# Altium schematic sheet 3_CLK_LMK04828.SchDoc
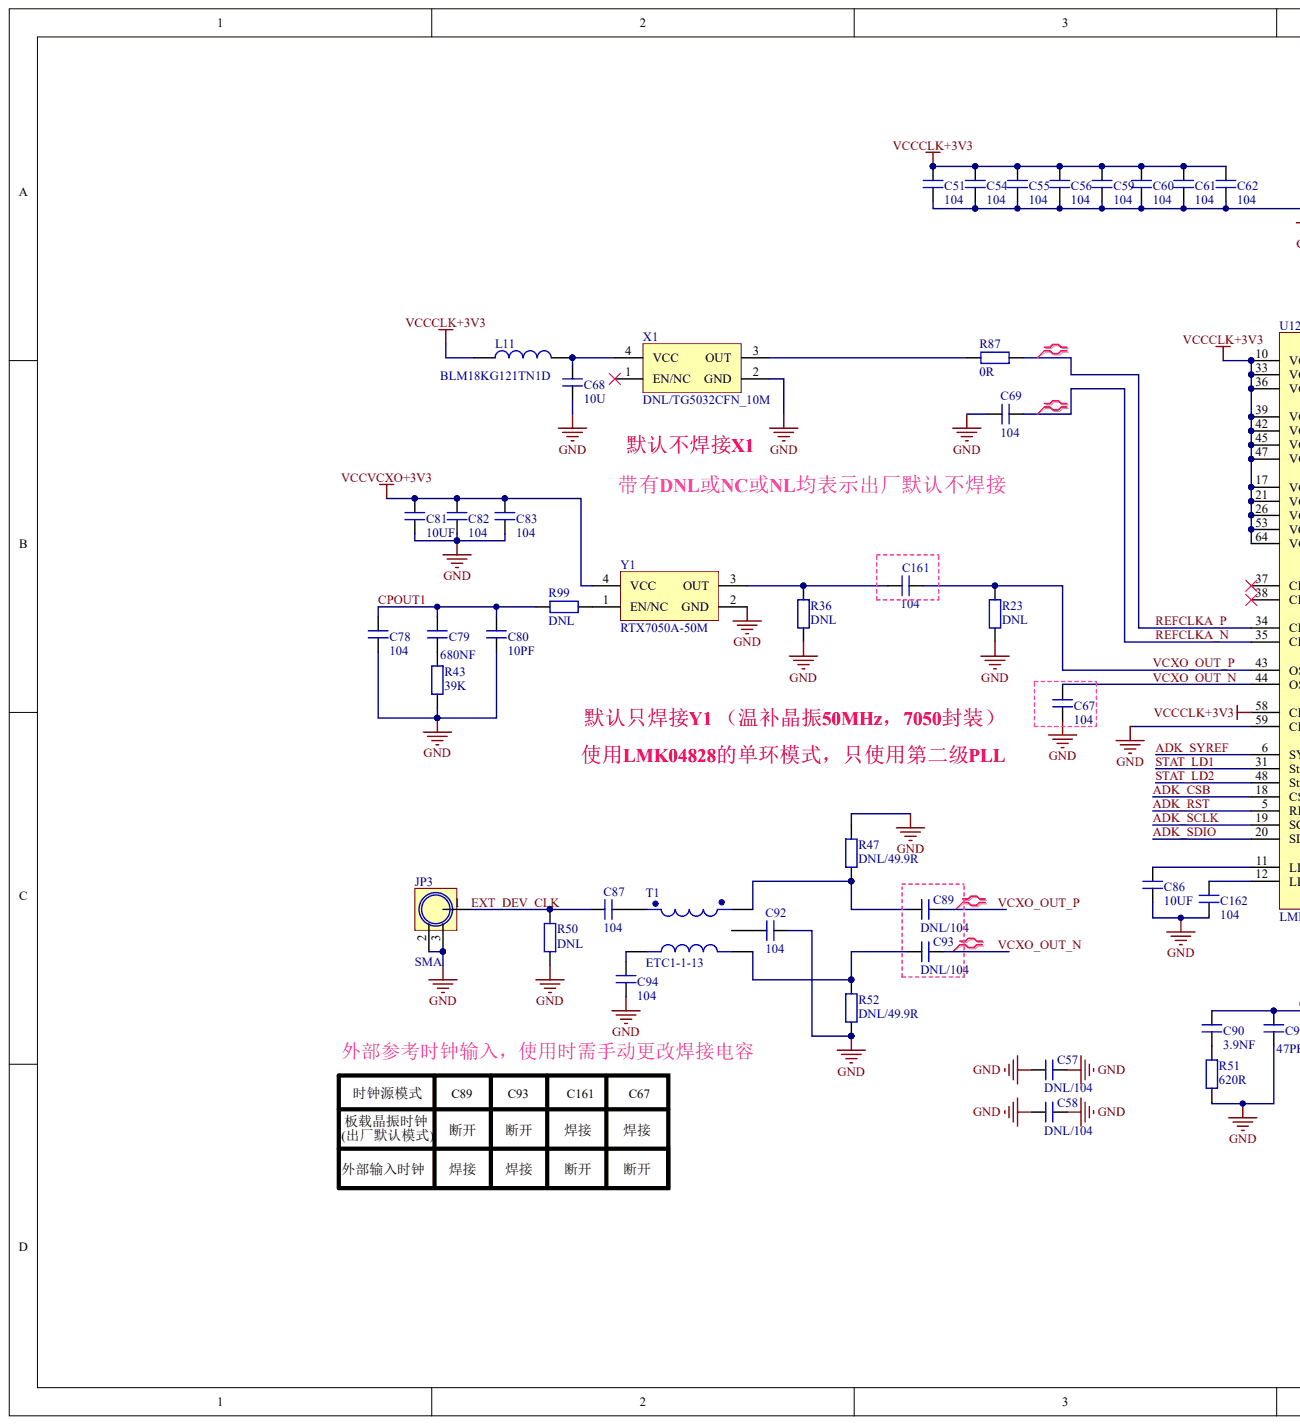
[diagram p0: 3_CLK_LMK04828.SchDoc, left]
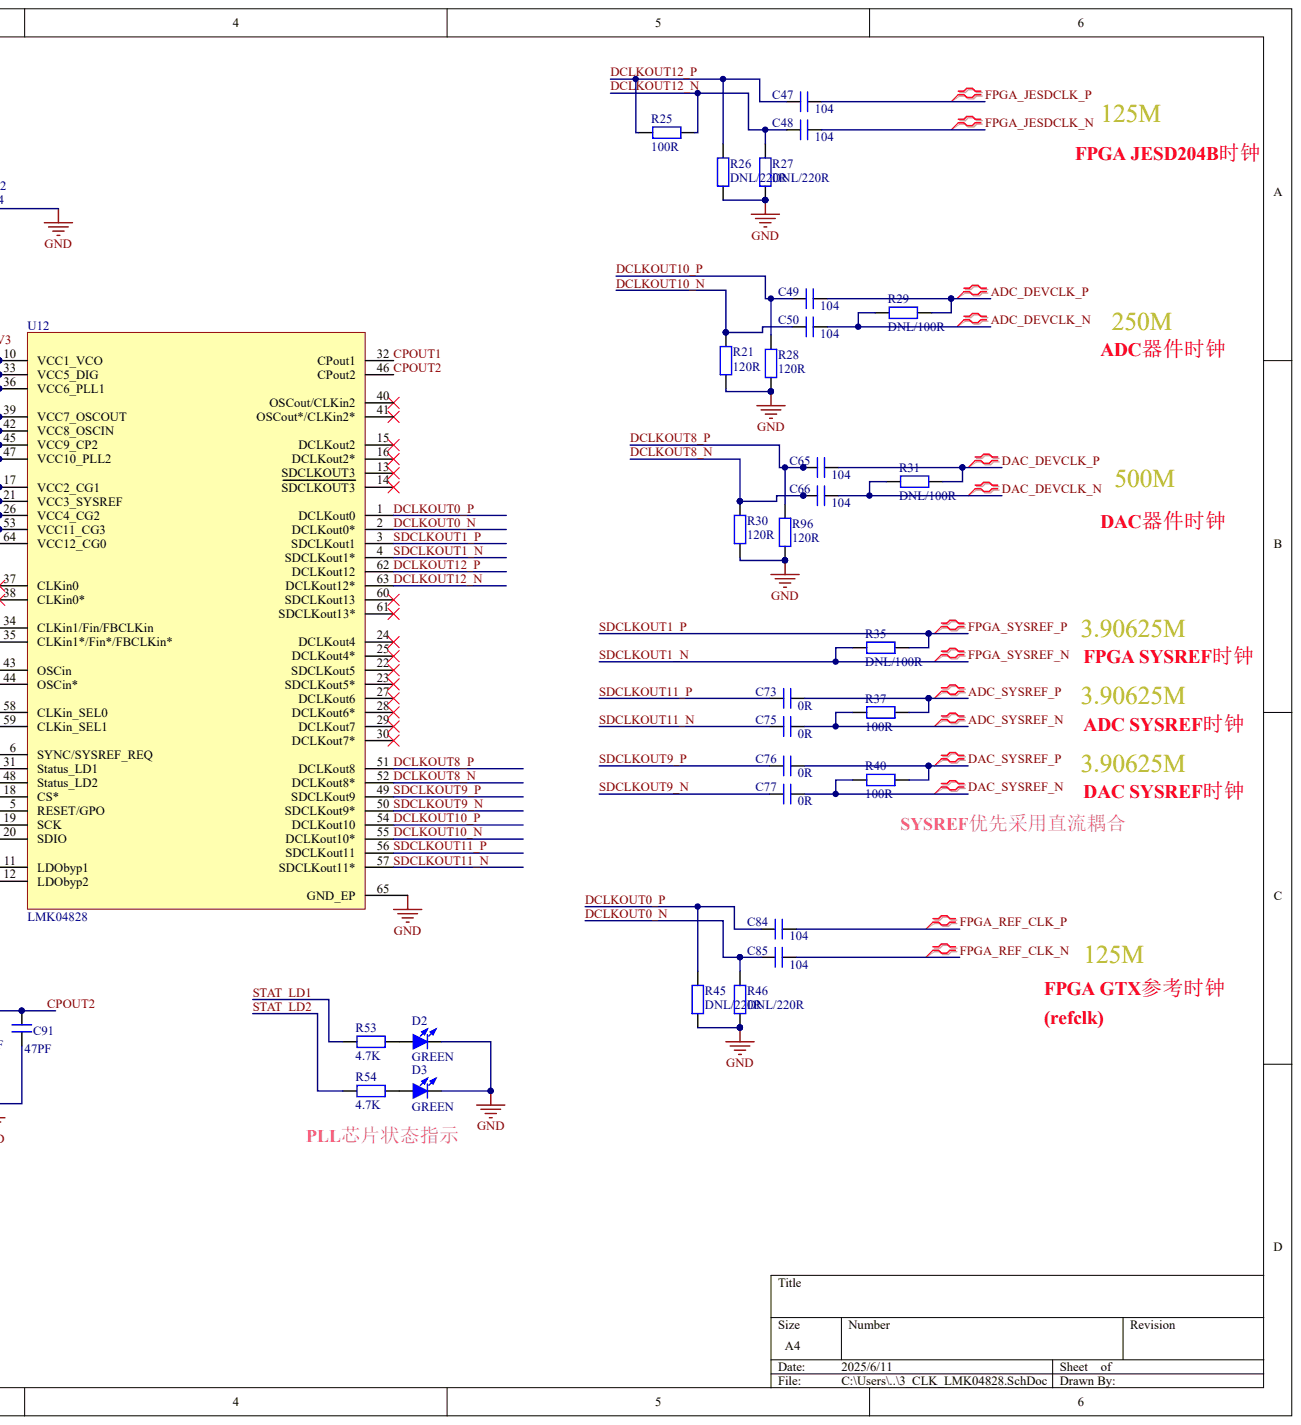
[diagram p1: 3_CLK_LMK04828.SchDoc, right]

## Parts
C47  104
C48  104
C49  104
C50  104
C51  104
C54  104
C55  104
C56  104
C57  DNL/104
C58  DNL/104
C59  104
C60  104
C61  104
C62  104
C65  104
C66  104
C67  104
C68  10U
C69  104
C73  0R
C75  0R
C76  0R
C77  0R
C78  104
C79  680NF
C80  10PF
C81  10UF
C82  104
C83  104
C84  104
C85  104
C86  10UF
C87  104
C89  DNL/104
C90  3.9NF
C91  47PF
C92  104
C93  DNL/104
C94  104
C161  104
C162  104
D2  GREEN
D3  GREEN
JP3  SMA
L11  BLM18KG121TN1D
R21  120R
R23  DNL
R25  100R
R26  DNL/220R
R27  DNL/220R
R28  120R
R29  DNL/100R
R30  120R
R31  DNL/100R
R35  DNL/100R
R36  DNL
R37  100R
R40  100R
R43  39K
R45  DNL/220R
R46  DNL/220R
R47  DNL/49.9R
R50  DNL
R51  620R
R52  DNL/49.9R
R53  4.7K
R54  4.7K
R87  0R
R96  120R
R99  DNL
T1  ETC1-1-13
U12  LMK04828
X1  DNL/TG5032CFN_10M
Y1  RTX7050A-50M

## Nets
GND: C51.1 C54.1 C55.1 C56.1 C57.1 C57.2 C58.1 C58.2 C59.1 C60.1 C61.1 C62.1 C67.1 C68.2 C69.1 C78.2 C80.2 C81.2 C82.2 C83.2 C86.2 C91.2 C92.1 C94.2 C162.1 D2.2 D3.2 JP3.2 JP3.3 R21.2 R23.1 R26.2 R27.2 R28.1 R30.2 R36.1 R43.1 R45.2 R46.2 R47.2 R50.2 R51.1 R52.1 R96.1 U12.59(CLKin_SEL1) U12.65(GND_EP) X1.2(GND) Y1.2(GND)
VCCCLK+3V3: C51.2 C54.2 C55.2 C56.2 C59.2 C60.2 C61.2 C62.2 L11.1 U12.10(VCC1_VCO) U12.17(VCC2_CG1) U12.21(VCC3_SYSREF) U12.26(VCC4_CG2) U12.33(VCC5_DIG) U12.36(VCC6_PLL1) U12.39(VCC7_OSCOUT) U12.42(VCC8_OSCIN) U12.45(VCC9_CP2) U12.47(VCC10_PLL2) U12.53(VCC11_CG3) U12.58(CLKin_SEL0) U12.64(VCC12_CG0)
VCCVCXO+3V3: C81.1 C82.1 C83.1 Y1.4(VCC)
ADC_DEVCLK_N: C50.2 R29.1
ADC_DEVCLK_P: C49.2 R29.2
ADC_SYSREF_N: C75.2 R37.1
ADC_SYSREF_P: C73.2 R37.2
ADK_CSB: U12.18(CS*)
ADK_RST: U12.5(RESET/GPO)
ADK_SCLK: U12.19(SCK)
ADK_SDIO: U12.20(SDIO)
ADK_SYREF: U12.6(SYNC/SYSREF_REQ)
CPOUT1: C78.1 C79.1 C80.1 R99.2 U12.32(CPout1)
CPOUT2: C90.1 C91.1 U12.46(CPout2)
DAC_DEVCLK_N: C66.2 R31.1
DAC_DEVCLK_P: C65.2 R31.2
DAC_SYSREF_N: C77.2 R40.1
DAC_SYSREF_P: C76.2 R40.2
DCLKOUT0_N: C85.1 R46.1 U12.2(DCLKout0*)
DCLKOUT0_P: C84.1 R45.1 U12.1(DCLKout0)
DCLKOUT8_N: C66.1 R30.1 U12.52(DCLKout8*)
DCLKOUT8_P: C65.1 R96.2 U12.51(DCLKout8)
DCLKOUT10_N: C50.1 R21.1 U12.55(DCLKout10*)
DCLKOUT10_P: C49.1 R28.2 U12.54(DCLKout10)
DCLKOUT12_N: C48.1 R25.2 R27.1 U12.63(DCLKout12*)
DCLKOUT12_P: C47.1 R25.1 R26.1 U12.62(DCLKout12)
EXT_DEV_CLK: C87.2 JP3.1 R50.1
FPGA_JESDCLK_N: C48.2
FPGA_JESDCLK_P: C47.2
FPGA_REF_CLK_N: C85.2
FPGA_REF_CLK_P: C84.2
FPGA_SYSREF_N: R35.1 U12.4(SDCLKout1*)
FPGA_SYSREF_P: R35.2 U12.3(SDCLKout1)
REFCLKA_N: C69.2 U12.35(CLKin1*/Fin*/FBCLKin*)
REFCLKA_P: R87.2 U12.34(CLKin1/Fin/FBCLKin)
SDCLKOUT9_N: C77.1 U12.50(SDCLKout9*)
SDCLKOUT9_P: C76.1 U12.49(SDCLKout9)
SDCLKOUT11_N: C75.1 U12.57(SDCLKout11*)
SDCLKOUT11_P: C73.1 U12.56(SDCLKout11)
STAT_LD1: R53.1 U12.31(Status_LD1)
STAT_LD2: R54.1 U12.48(Status_LD2)
VCXO_OUT_N: C67.2 C93.1 U12.44(OSCin*)
VCXO_OUT_P: C89.1 C161.2 R23.2 U12.43(OSCin)
NetC68_1: C68.1 L11.2 X1.4(VCC)
NetC79_2: C79.2 R43.2
NetC86_1: C86.1 U12.11(LDObyp1)
NetC87_1: C87.1 T1.5
NetC89_2: C89.2 R47.1 T1.1
NetC90_2: C90.2 R51.2
NetC92_2: C92.2 T1.2
NetC93_2: C93.2 R52.2 T1.3
NetC94_1: C94.1 T1.4
NetC161_1: C161.1 R36.2 Y1.3(OUT)
NetC162_2: C162.2 U12.12(LDObyp2)
NetD2_1: D2.1 R53.2
NetD3_1: D3.1 R54.2
NetR87_1: R87.1 X1.3(OUT)
NetR99_1: R99.1 Y1.1(EN/NC)

Not connected: U12 13(SDCLKOUT3) 14(SDCLKOUT3) 15(DCLKout2) 16(DCLKout2*) 22(SDCLKout5) 23(SDCLKout5*) 24(DCLKout4) 25(DCLKout4*) 27(DCLKout6) 28(DCLKout6*) 29(DCLKout7) 30(DCLKout7*) 37(CLKin0) 38(CLKin0*) 40(OSCout/CLKin2) 41(OSCout*/CLKin2*) 60(SDCLKout13) 61(SDCLKout13*); X1 1(EN/NC)

## Library data of the parts
D2 D3 = (no symbol name): BOM名称: 贴片LED; 卖家免费查找封装，联系售后: 唯一出售店铺网址https://shop463601444.taobao.com; : 其他店铺购入均为假冒，请立即申请退款，本店奖励2~5元现金
JP3 = (no symbol name): BOM名称: SMA-2.4G射频天线接口; 唯一出售店铺网址: https://shop463601444.taobao.com
R21 R23 R25-R31 R35-R37 R40 R43 R45-R47 R50-R54 R87 R96 R99 = (no symbol name): BOM名称: 贴片电阻; : 联系淘宝ID：黄晶宝石，获取更新版封装库; : 唯一出售店铺网址https://shop463601444.taobao.com
X1 Y1 = (no symbol name): 卖家免费技术支持，联系售后: 唯一出售店铺网址https://shop463601444.taobao.com; : 联系淘宝ID：黄晶宝石，获取更新版封装库
C47-C51 C54-C62 C65-C69 C73 C75-C87 C89-C94 C161 C162 L11 T1 U12 = (no symbol name)
  C47-C51 C54-C62 C65-C69 C73 C75-C87 C89-C94 C161 C162 L11: : 联系淘宝ID：黄晶宝石，获取更新版封装库; : 唯一出售店铺网址https://shop463601444.taobao.com
